# Altium schematic sheet ch340-usb-serial.SchDoc(ch340-usb-serial)
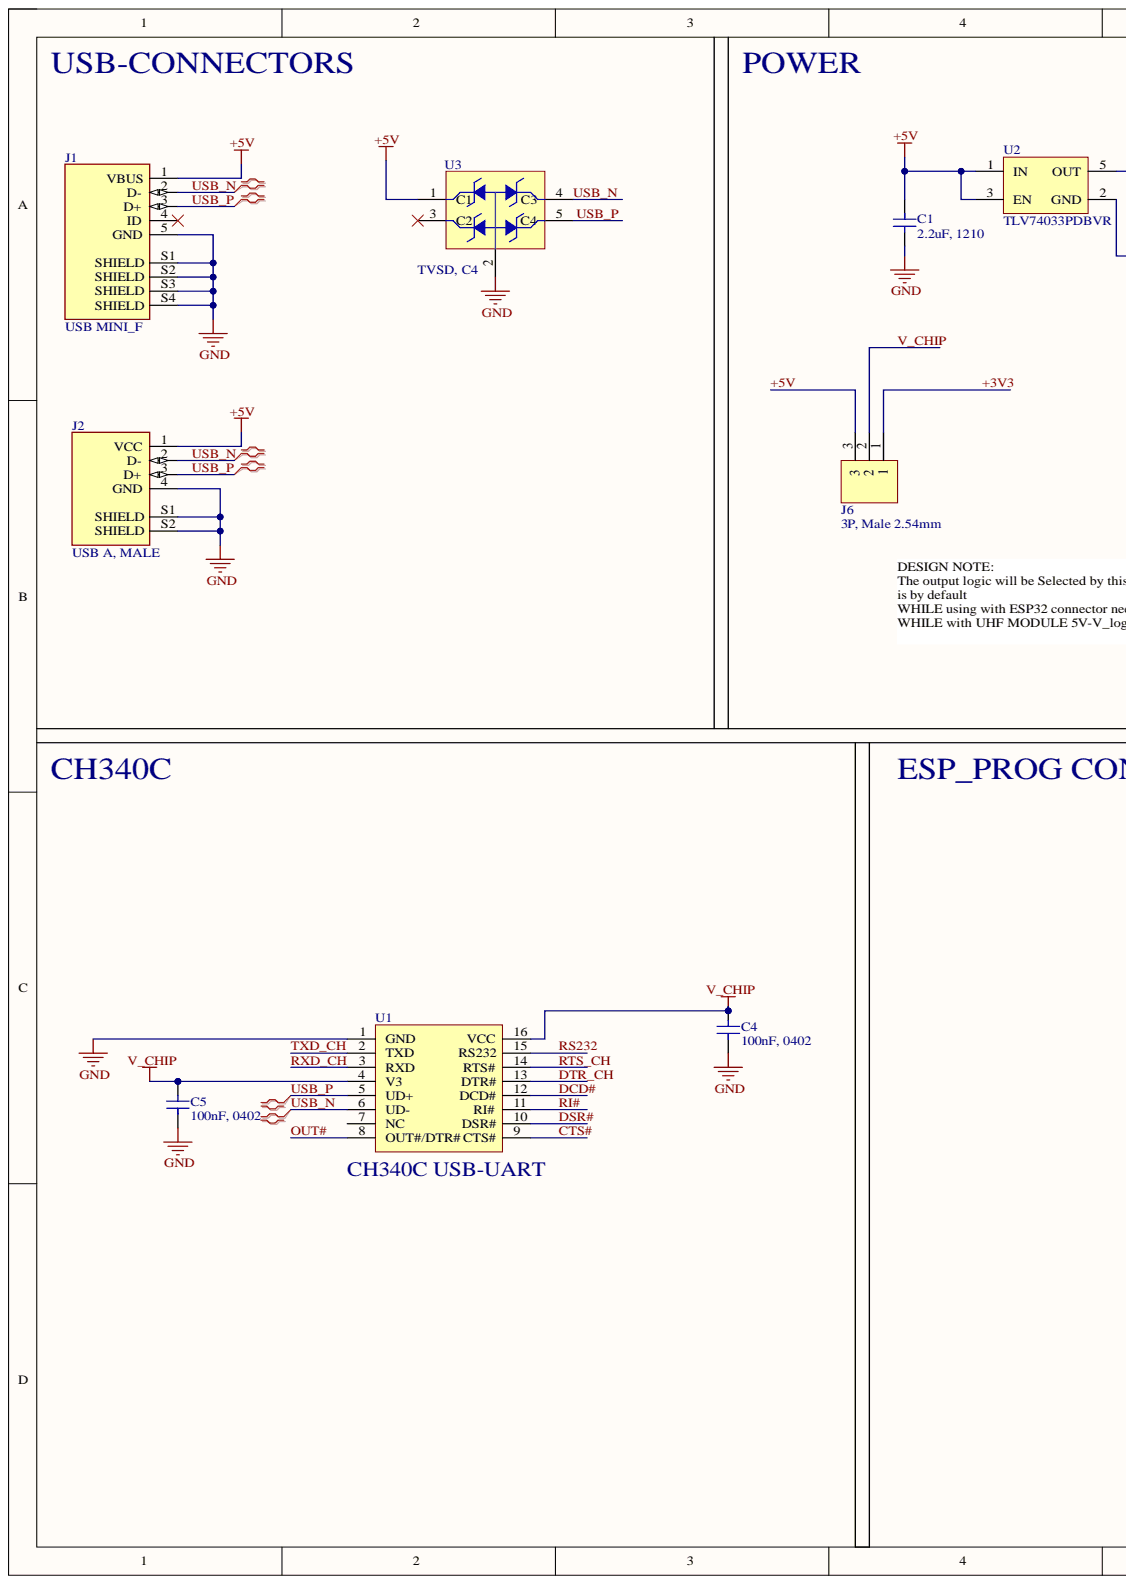
[diagram p0: ch340-usb-serial.SchDoc, left]
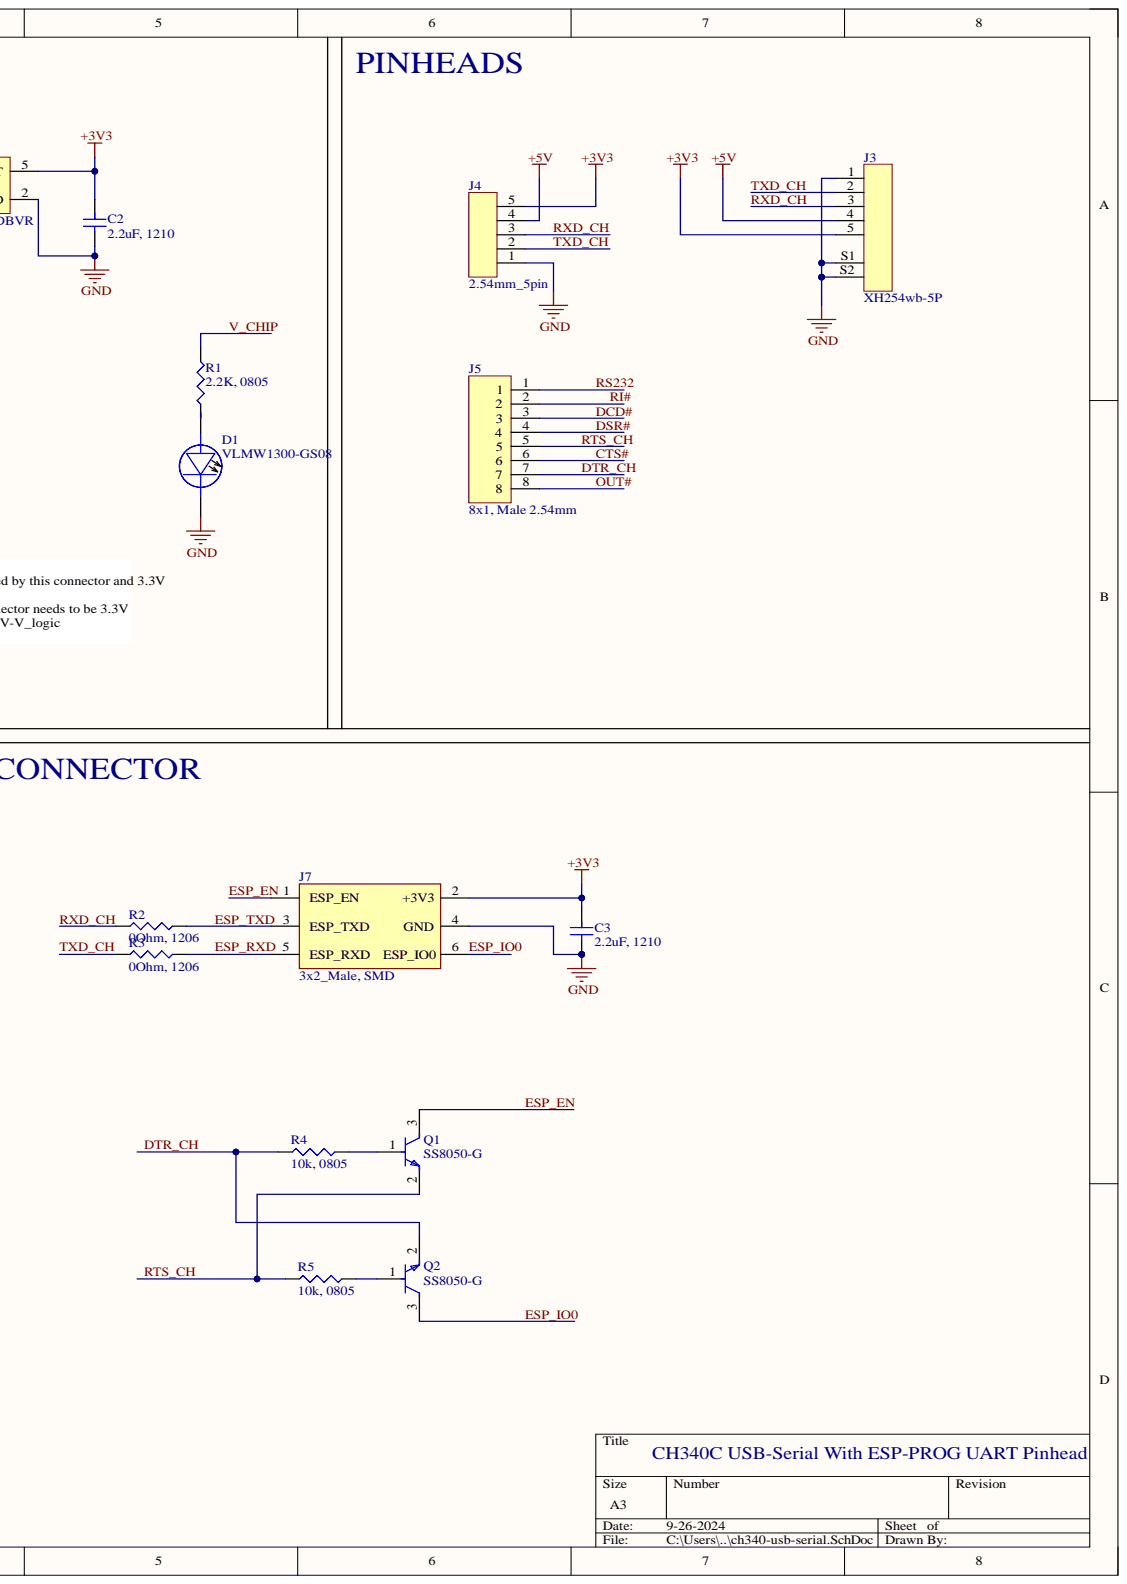
[diagram p1: ch340-usb-serial.SchDoc, right]

## Parts
C1  2.2uF, 1210  [CAP_1210]
C2  2.2uF, 1210  [CAP_1210]
C3  2.2uF, 1210  [CAP_1210]
C4  100nF, 0402  [CAP_0402]
C5  100nF, 0402  [CAP_0402]
D1  VLMW1300-GS08  [LED_0603]
J1  USB MINI_F  [USB_B_Female]
J2  USB A, MALE  Connector Type: USB  [USB_A_MALE]
J3  XH254wb-5P  [5PIN_Header_Connectotor]
J4  2.54mm_5pin  [5x1P_Male_2.54]
J5  8x1, Male 2.54mm  Contact Gender: Male  Terminal Pitch: 2.54mm  [8x1P_Male_2.54]
J6  3P, Male 2.54mm  Contact Gender: Male  Terminal Pitch: 2.54mm  [3x1P_Male_2.54]
J7  3x2_Male, SMD  [3x2P_Male_SMD_1.27]
Q1  SS8050-G  [FP-SS8050-G-IPC_B]
Q2  SS8050-G  [FP-SS8050-G-IPC_B]
R1  2.2K, 0805  [RES_0805]
R2  0Ohm, 1206  Case/Package: 1206  [RES_1206]
R3  0Ohm, 1206  Case/Package: 1206  [RES_1206]
R4  10k, 0805  Case/Package: 0805  [RES_0805]
R5  10k, 0805  Case/Package: 0805  [RES_0805]
U1  USB-UART  [SOP16]
U2  TLV74033PDBVR  [SOT95P280X145-5N]
U3  TVSD, C4  [SOT353]

## Nets
GND: C1.2 C2.2 C3.2 C4.1 C5.1 D1.K- J1.5(GND) J1.S1(SHIELD) J1.S2(SHIELD) J1.S3(SHIELD) J1.S4(SHIELD) J2.4(GND) J2.S1(SHIELD) J2.S2(SHIELD) J3.1 J3.S1 J3.S2 J4.1 J7.4(GND) U1.1(GND) U2.2(GND) U3.2
+3V3: C2.1 C3.1 J3.5 J4.5 J6.1 J7.2(+3V3) U2.5(OUT)
+5V: C1.1 J1.1(VBUS) J2.1(VCC) J3.4 J4.4 J6.3 U2.1(IN) U2.3(EN) U3.1(C1)
CTS#: J5.6 U1.9(CTS#)
DCD#: J5.3 U1.12(DCD#)
DSR#: J5.4 U1.10(DSR#)
DTR_CH: J5.7 Q2.2 R4.1 U1.13(DTR#)
ESP_EN: J7.1(ESP_EN) Q1.3
ESP_IO0: J7.6(ESP_IO0) Q2.3
ESP_RXD: J7.5(ESP_RXD) R3.2
ESP_TXD: J7.3(ESP_TXD) R2.2
OUT#: J5.8 U1.8(OUT#/DTR#)
RI#: J5.2 U1.11(RI#)
RS232: J5.1 U1.15(RS232)
RTS_CH: J5.5 Q1.2 R5.1 U1.14(RTS#)
RXD_CH: J3.3 J4.3 R2.1 U1.3(RXD)
TXD_CH: J3.2 J4.2 R3.1 U1.2(TXD)
USB_N: J1.2(D-) J2.2(D-) U1.6(UD-) U3.4(C3)
USB_P: J1.3(D+) J2.3(D+) U1.5(UD+) U3.5
V_CHIP: C4.2 C5.2 J6.2 R1.2 U1.4(V3) U1.16(VCC)
NetD1_A+: D1.A+ R1.1
NetQ1_1: Q1.1 R4.2
NetQ2_1: Q2.1 R5.2

Not connected: J1 4(ID); U1 7(NC); U3 3(C2)

## Library data of the parts
C1-C3 = CL32B225KCJSNNE: CAP CER 2.2UF 100V X7R 1210; Manufacturer: Samsung; Capacitor Type: Ceramic; Multilayer: Yes; Tolerance  (fill in as +-): 10%; Case/Package: 1210; Category: Ceramic Capacitors; Component Kind: Standard; ComponentLink1Description: https://datasheet.ciiva.com:443/pdfs/VipMasterIC/IC/SEMS/SEMS-S-A0008526420/SEMS-S-A0008525306-1.pdf?src-supplier=IHS+Markit; ComponentLink1URL: https://datasheet.ciiva.com:443/pdfs/VipMasterIC/IC/SEMS/SEMS-S-A0008526420/SEMS-S-A0008525306-1.pdf?src-supplier=IHS+Markit; ComponentLink2Description: https://datasheet.ciiva.com/25791/cl32b225kcjsnne-25791527.pdf?src-supplier=Digikey; ComponentLink2URL: https://datasheet.ciiva.com/25791/cl32b225kcjsnne-25791527.pdf?src-supplier=Digikey; ComponentLink3Description: https://datasheet.ciiva.com/26947/getdatasheetpartid-357497-26947037.pdf?src-supplier=Verical; ComponentLink3URL: https://datasheet.ciiva.com/26947/getdatasheetpartid-357497-26947037.pdf?src-supplier=Verical; ComponentLink4Description: https://datasheet.ciiva.com/16437/cl32b225kcjsnne-16437175.pdf?src-supplier=Future+Electronics; ComponentLink4URL: https://datasheet.ciiva.com/16437/cl32b225kcjsnne-16437175.pdf?src-supplier=Future+Electronics; Dielectric Material: Ceramic; JESD-609 Code: e3; Library Name: Schlib.SchLib; Manufacturer Part Number: CL32B225KCJSNNE; Max Operating Temperature: 125°C; Min Operating Temperature: -55°C; Mounting Technology: Surface Mount; Package Shape: Rectangular; Packaging: Tape and Reel; Pins: 2; Pricing: 1=0.43, 10=0.286, 50=0.228, 100=0.1882, 500=0.14706 (USD); Rated DC Voltage (URdc): 100V; Reach SVHC Compliant: Yes; RoHS: Yes; RoHS Compliant: Yes; Stock: 239814; Supplier: Digikey; Supplier Part Number: 1276-3362-6-ND; Temperature Characteristics Code: X7R; Terminal Finish: Nickel; Termination: Wraparound; Tolerance (fill in as +-): 10%; Value: 2.2uF
C4 C5 = CL05B104KB54PNC: 0.1 µF ±10% 50V Ceramic Capacitor X7R 0402 (1005 Metric); Manufacturer: Samsung; Material: 4241ConductorMaterial; Case/Package: 343Case; Category: Ceramic Capacitors; Component Kind: Standard; ComponentLink1Description: https://datasheet.octopart.com/CL05B104KB54PNC-Samsung-datasheet-8326407.pdf?src-supplier=TME; ComponentLink1URL: https://datasheet.octopart.com/CL05B104KB54PNC-Samsung-datasheet-8326407.pdf?src-supplier=TME; Height: 550um; LCSC Part Number: C307331; Library Name: Schlib.SchLib; Manufacturer Part Number: CL05B104KB54PNC; Max Operating Temperature: 125°C; Min Operating Temperature: -55°C; Pricing: 10000=0.0057 (USD); RoHS: Yes; RoHS Compliant: Yes; Stock: 2120000; Supplier: Arrow Electronics; Supplier Part Number: CL05B104KB54PNC; Tolerance: 10%; Value: 100nF; Voltage Rating: 50V
D1 = VLMW1300-GS08: LED COOL WHITE 0603 SMD; Manufacturer: Vishay Semiconductors; Additional Feature: HIGH RELIABILITY; Channels: 1; Forward Current: 20mA; Forward Voltage: 3.15V; Luminous Intensity-Nom: 112.5mcd; Optoelectronic Device Type: SINGLE COLOR LED; Overall Height: 0.55mm; Shape: Rectangular; Viewing Angle: 130°; Category: LEDs; Color: White; Color@wavelength: White; Component Kind: Standard; ComponentLink1Description: https://datasheet.ciiva.com:443/pdfs/VipMasterIC/IC/VISH/VISH-S-A0001807432/VISH-S-A0001807432-1.pdf?src-supplier=IHS+Markit; ComponentLink1URL: https://datasheet.ciiva.com:443/pdfs/VipMasterIC/IC/VISH/VISH-S-A0001807432/VISH-S-A0001807432-1.pdf?src-supplier=IHS+Markit; ComponentLink2Description: https://datasheet.ciiva.com/6858/vlmw1300-6858354.pdf?src-supplier=Newark; ComponentLink2URL: https://datasheet.ciiva.com/6858/vlmw1300-6858354.pdf?src-supplier=Newark; ComponentLink3Description: https://datasheet.ciiva.com/4688/vlmw1300-98499-4688159.pdf?src-supplier=Mouser; ComponentLink3URL: https://datasheet.ciiva.com/4688/vlmw1300-98499-4688159.pdf?src-supplier=Mouser; ComponentLink4Description: https://datasheet.ciiva.com/14650/vlmw1300-14650411.pdf?src-supplier=Element14; ComponentLink4URL: https://datasheet.ciiva.com/14650/vlmw1300-14650411.pdf?src-supplier=Element14; ComponentLink5Description: https://datasheet.ciiva.com/14650/vlmw1300-14650412.pdf?src-supplier=Element14; ComponentLink5URL: https://datasheet.ciiva.com/14650/vlmw1300-14650412.pdf?src-supplier=Element14; ComponentLink6Description: https://datasheet.ciiva.com/16388/vlmw1300-16388055.pdf?src-supplier=Future+Electronics; ComponentLink6URL: https://datasheet.ciiva.com/16388/vlmw1300-16388055.pdf?src-supplier=Future+Electronics; Library Name: Schlib.SchLib; Manufacturer Part Number: VLMW1300-GS08; Max Operating Temperature: 80°C; Min Operating Temperature: -20°C; Mounting Technology: Surface Mount; Packaging: Tape and Reel; Pins: 2; Pricing: 1=0.46, 10=0.266, 100=0.1687, 500=0.13056, 1000=0.11887 (USD); Reach SVHC Compliant: Yes; RoHS: Yes; RoHS Compliant: Yes; Size: 1.1mm; Stock: 7313; Supplier: Digikey; Supplier Part Number: VLMW1300-GS08CT-ND; Terminal Pitch: 1.5mm
J1 = GMSB0532112YEU: MINI USB B TYPE RECEPTACLE 5POS; Manufacturer: Amphenol; Category: Connectors; Component Kind: Standard; Library Name: Schlib.SchLib; Manufacturer Part Number: GMSB0532112YEU; Pricing: 1=0.55, 10=0.476, 25=0.4352, 50=0.4094, 100=0.3924, 250=0.35824, 500=0.34118 (USD); RoHS: No; Stock: 5615; Supplier: Digikey; Supplier Part Number: 664-GMSB0532112YEUDKR-ND
J2 = 0480372200: CONN PLUG USB2.0 TYPEA 4P SMD RA; Manufacturer: Molex; DIN Conformance: No; Mating Information: MULTIPLE MATING PARTS AVAILABLE; Number of Contacts: 4; Option: General Purpose; Body/Shell Style: PLUG; Component Kind: Standard; ComponentLink1Description: https://datasheet.ciiva.com:443/pdfs/VipMasterIC/IC/MOLE/MOLES33218/MOLES28897-1.pdf?src-supplier=IHS+Markit; ComponentLink1URL: https://datasheet.ciiva.com:443/pdfs/VipMasterIC/IC/MOLE/MOLES33218/MOLES28897-1.pdf?src-supplier=IHS+Markit; Contact Finish - Mating: GOLD (30); Contact Finish - Termination: TIN; Contact Gender: Male; Empty Shell: No; Filter Feature: No; IEC Conformance: No; JESD-609 Code: e3; Library Name: Schlib.SchLib; Manufacturer Part Number: 0480372200; MIL Conformance: No; Mixed Contacts: No; Mounting Style: RIGHT ANGLE; Mounting Technology: Panel,Board; Pricing: 1=1.45, 10=1.199, 100=0.9993 (USD); Reach SVHC Compliant: Yes; RoHS: Yes; RoHS Compliant: Yes; Stock: 18158; Supplier: Digikey; Supplier Part Number: WM3983CT-ND; Termination: SMD/SMT
J3 = XH254wb-5P: SMT SMD Horizontal Socket Male Header Connector; Component Kind: Standard; Library Name: Schlib.SchLib
J4 = 2.54mm_5pin: CONN HEADER VERT 5POS 2.54MM; Component Kind: Standard; Library Name: Schlib.SchLib
J5 = 61300811121: CONN HEADER VERT 8POS 2.54MM; Manufacturer: Wurth Electronics; Manufacturer Series: 613; Body Breadth: 0.1inch; Body Length: 0.8inch; Body/Shell Style: PLUG; Connector Type: BOARD CONNECTOR; Contact Pattern: RECTANGULAR; Contact Resistance: 20mR; Contact Style: SQ PIN-SKT; Dielectric Withstanding Voltage (AC): 500V; Insulation Resistance: 1GR; Mounting Option 1: LOCKING; Number Of Connectors: 1; Number of Contacts: 8; Rated Current (Signal): 3A; Reference Standard: UL; Reliability: COMMERCIAL; Body Depth: 0.1inch; Category: Headers and Wire Housings; Component Kind: Standard; ComponentLink1Description: https://datasheet.ciiva.com:443/pdfs/VipMasterIC/IC/MIDC/MIDCS07676/MIDCS07676-1.pdf?src-supplier=IHS+Markit; ComponentLink1URL: https://datasheet.ciiva.com:443/pdfs/VipMasterIC/IC/MIDC/MIDCS07676/MIDCS07676-1.pdf?src-supplier=IHS+Markit; ComponentLink2Description: https://datasheet.octopart.com/61300811121-W%C3%BCrth-Elektronik-datasheet-21154836.pdf?src-supplier=Element14; ComponentLink2URL: https://datasheet.octopart.com/61300811121-W%C3%BCrth-Elektronik-datasheet-21154836.pdf?src-supplier=Element14; ComponentLink3Description: https://katalog.we-online.de/em/datasheet/6130xx11121.pdf?src-supplier=Digikey; ComponentLink3URL: https://katalog.we-online.de/em/datasheet/6130xx11121.pdf?src-supplier=Digikey; Contact Finish - Mating: AU; Contact Finish - Termination: Gold (Au) - with Nickel (Ni) barrier; Contact Material: Copper Alloy; Insulation Color: Black; Insulation Material: Polybutylene; JESD-609 Code: e4; Library Name: Schlib.SchLib; Manufacturer Part Number: 61300811121; Mating Contact Pitch: 0.1inch; Max Operating Temperature: 125°C; Min Operating Temperature: -40°C; Mounting Style: STRAIGHT; Mounting Technology: Board; Number of Rows Loaded: 1; PCB Contact Pattern: RECTANGULAR; Pricing: 1=0.39, 10=0.336, 25=0.28, 50=0.234, 100=0.212, 1000=0.193 (USD); Reach SVHC Compliant: Yes; RoHS: Yes; RoHS Compliant: Yes; Stock: 8009; Supplier: Digikey; Supplier Part Number: 732-5321-ND; Terminal Length: 0.118inch; Termination: Solder
J6 = 61300311121: CONN HEADER VERT 3POS 2.54MM; Manufacturer: Wurth Electronics; Body Breadth: 0.1inch; Body Length: 0.3inch; Body/Shell Style: PLUG; Connector Type: BOARD CONNECTOR; Contact Pattern: RECTANGULAR; Contact Resistance: 20mR; Contact Style: SQ PIN-SKT; Dielectric Withstanding Voltage (AC): 500V; Insulation Resistance: 1GR; Mounting Option 1: LOCKING; Number Of Connectors: 1; Number of Contacts: 3; Rated Current (Signal): 3A; Reference Standard: UL; Reliability: COMMERCIAL; Body Depth: 0.1inch; Category: Headers and Wire Housings; Component Kind: Standard; ComponentLink1Description: https://datasheet.ciiva.com:443/pdfs/VipMasterIC/IC/MIDC/MIDCS07676/MIDCS07676-1.pdf?src-supplier=IHS+Markit; ComponentLink1URL: https://datasheet.ciiva.com:443/pdfs/VipMasterIC/IC/MIDC/MIDCS07676/MIDCS07676-1.pdf?src-supplier=IHS+Markit; ComponentLink2Description: http://www.farnell.com/cad/1737268.pdf?src-supplier=Element14; ComponentLink2URL: http://www.farnell.com/cad/1737268.pdf?src-supplier=Element14; ComponentLink3Description: https://datasheet.octopart.com/61300311121-W%C3%BCrth-Elektronik-datasheet-21154836.pdf?src-supplier=Element14; ComponentLink3URL: https://datasheet.octopart.com/61300311121-W%C3%BCrth-Elektronik-datasheet-21154836.pdf?src-supplier=Element14; ComponentLink4Description: https://katalog.we-online.de/em/datasheet/6130xx11121.pdf?src-supplier=Digikey; ComponentLink4URL: https://katalog.we-online.de/em/datasheet/6130xx11121.pdf?src-supplier=Digikey; Contact Finish - Mating: GOLD; Contact Finish - Termination: Gold (Au) - with Nickel (Ni) barrier; Contact Material: Copper Alloy; Insulation Color: Black; Insulation Material: Polybutylene; JESD-609 Code: e4; Library Name: Schlib.SchLib; Manufacturer Part Number: 61300311121; Mating Contact Pitch: 0.1inch; Max Operating Temperature: 125°C; Min Operating Temperature: -40°C; Mounting Style: STRAIGHT; Mounting Technology: Board; Number of Rows Loaded: 1; PCB Contact Pattern: RECTANGULAR; Pricing: 1=0.13, 10=0.113, 25=0.094, 50=0.079, 100=0.071, 1000=0.066 (USD); Reach SVHC Compliant: Yes; RoHS: Yes; RoHS Compliant: Yes; Stock: 134142; Supplier: Digikey; Supplier Part Number: 732-5316-ND; Terminal Length: 0.118inch; Termination: Solder
J7 = 20021121-00006C4LF: CONN HEADER SMD 6POS 1.27MM; Manufacturer: Amphenol Communications Solutions; Category: Headers and Wire Housings; Component Kind: Standard; Library Name: Schlib.SchLib; Manufacturer Part Number: 20021121-00006C4LF; Pricing: 1=0.8, 10=0.612, 100=0.4694 (USD); RoHS: No; Stock: 7493; Supplier: Digikey; Supplier Part Number: 609-3693-1-ND
Q1 Q2 = SS8050-G: TRANS NPN 25V 1.5A SOT23-3; Manufacturer: Comchip; Category: Transistors; Component Kind: Standard; ComponentLink1Description: https://datasheet.ciiva.com:443/pdfs/VipMasterIC/IC/COMC/COMC-S-A0004994192/COMC-S-A0004994192-1.pdf?src-supplier=IHS+Markit; ComponentLink1URL: https://datasheet.ciiva.com:443/pdfs/VipMasterIC/IC/COMC/COMC-S-A0004994192/COMC-S-A0004994192-1.pdf?src-supplier=IHS+Markit; ComponentLink2Description: http://www.comchiptech.com/admin/files/product/SS8050-G RevA181526.pdf?src-supplier=Digikey; ComponentLink2URL: http://www.comchiptech.com/admin/files/product/SS8050-G RevA181526.pdf?src-supplier=Digikey; LCSC Part Number: C164886; Library Name: Schlib.SchLib; Manufacturer Part Number: SS8050-G; Pricing: 1=0.29, 10=0.2, 100=0.0978, 500=0.08154, 1000=0.05666 (USD); Reach SVHC Compliant: Yes; RoHS: Yes; RoHS Compliant: Yes; Stock: 90458; Supplier: Digikey; Supplier Part Number: 641-1790-1-ND
R1 = CRCW08052K20FKEC: RES SMD 2.2K OHM 1% 1/8W 0805; Manufacturer: Vishay Dale; Package Height: 0.45mm; Package Length: 2mm; Package Width: 1.25mm; Power: 125mW; Rated Temperature: 70°C; Reference Standard: AEC-Q200; Temperature Coefficient: 100ppm/°C; Value: 2.2kR; Working Voltage: 150V; Case/Package: 0805; Category: Chip SMD Resistors; Component Kind: Standard; ComponentLink1Description: https://datasheet.ciiva.com:443/pdfs/VipMasterIC/IC/VISH/VISH-S-A0007266705/VISH-S-A0007266705-1.pdf?src-supplier=IHS+Markit; ComponentLink1URL: https://datasheet.ciiva.com:443/pdfs/VipMasterIC/IC/VISH/VISH-S-A0007266705/VISH-S-A0007266705-1.pdf?src-supplier=IHS+Markit; ComponentLink2Description: http://www.vishay.com/docs/20035/dcrcwe3.pdf?src-supplier=Digikey; ComponentLink2URL: http://www.vishay.com/docs/20035/dcrcwe3.pdf?src-supplier=Digikey; Construction: Chip; JESD-609 Code: e3; Library Name: Schlib.SchLib; Manufacturer Part Number: CRCW08052K20FKEC; Max Operating Temperature: 155°C; Min Operating Temperature: -55°C; Mounting Technology: Surface Mount; Package Shape: Rectangular; Package Style: SMTMeter; Packaging: Tape and Reel; Pins: 2; Pricing: 1=0.1, 10=0.039, 50=0.025, 100=0.021, 500=0.01444, 1000=0.0125, 5000=0.00933, 10000=0.00838 (USD); Reach SVHC Compliant: Yes; Resistor Type: FIXED RESISTOR; RoHS: Yes; RoHS Compliant: Yes; Stock: 19945; Supplier: Digikey; Supplier Part Number: 541-CRCW08052K20FKECCT-ND; Technology: METAL GLAZE/THICK FILM; Terminal Finish: Nickel; Termination: Wraparound; Tolerance: 1%
R2 R3 = RC1206JR-070RL: RES 0 OHM JUMPER 1/4W 1206; Manufacturer: Yageo; Package Height: 0.55mm; Package Length: 3.1mm; Package Width: 1.6mm; Power: 250mW; Rated Temperature: 70°C; Value: 0R; Category: Chip SMD Resistors; Component Kind: Standard; ComponentLink1Description: https://datasheet.ciiva.com:443/pdfs/VipMasterIC/IC/YAGO/YAGO-S-A0008145938/YAGO-S-A0008145938-1.pdf?src-supplier=IHS+Markit; ComponentLink1URL: https://datasheet.ciiva.com:443/pdfs/VipMasterIC/IC/YAGO/YAGO-S-A0008145938/YAGO-S-A0008145938-1.pdf?src-supplier=IHS+Markit; ComponentLink2Description: https://datasheet.ciiva.com/11808/0900766b80c6630a-11808156.pdf?src-supplier=Rs; ComponentLink2URL: https://datasheet.ciiva.com/11808/0900766b80c6630a-11808156.pdf?src-supplier=Rs; ComponentLink3Description: https://datasheet.ciiva.com/29181/402222-da-01-en-smd-widerstand-0-ohm-1206-29181568.pdf?src-supplier=Conrad; ComponentLink3URL: https://datasheet.ciiva.com/29181/402222-da-01-en-smd-widerstand-0-ohm-1206-29181568.pdf?src-supplier=Conrad; ComponentLink4Description: https://datasheet.ciiva.com/22747/1692832-22747541.pdf?src-supplier=Element14; ComponentLink4URL: https://datasheet.ciiva.com/22747/1692832-22747541.pdf?src-supplier=Element14; ComponentLink5Description: https://datasheet.ciiva.com/46445/444357-46445719.pdf?src-supplier=Element14; ComponentLink5URL: https://datasheet.ciiva.com/46445/444357-46445719.pdf?src-supplier=Element14; ComponentLink6Description: https://datasheet.ciiva.com/17035/pyu-rc1206-51-rohs-l-4-17035512.pdf?src-supplier=Future+Electronics; ComponentLink6URL: https://datasheet.ciiva.com/17035/pyu-rc1206-51-rohs-l-4-17035512.pdf?src-supplier=Future+Electronics; Construction: Chip; JESD-609 Code: e3; Library Name: Schlib.SchLib; Manufacturer Part Number: RC1206JR-070RL; Max Operating Temperature: 155°C; Min Operating Temperature: -55°C; Mounting Technology: Surface Mount; Package Shape: Rectangular; Package Style: SMTMeter; Packaging: Tape and Reel; Pins: 2; Pricing: 1=0.1, 10=0.048, 25=0.0364, 50=0.0276, 100=0.0193, 250=0.01556, 500=0.01244, 1000=0.00915 (USD); Reach SVHC Compliant: Yes; Resistor Type: FIXED RESISTOR; RoHS: Yes; RoHS Compliant: Yes; Stock: 903635; Supplier: Digikey; Supplier Part Number: 311-0.0ERCT-ND; Technology: METAL GLAZE/THICK FILM; Terminal Finish: Nickel; Termination: Wraparound
R4 R5 = RC0805FR-0710KL: RES 10K OHM 1% 1/8W 0805; Manufacturer: Yageo; Additional Feature: LASER TRIMMABLE; Package Height: 0.5mm; Package Length: 2mm; Package Width: 1.25mm; Power: 125mW; Rated Temperature: 70°C; Temperature Coefficient: 100ppm/°C; Value: 10kR; Working Voltage: 150V; Category: Chip SMD Resistors; Component Kind: Standard; ComponentLink1Description: https://datasheet.ciiva.com:443/pdfs/VipMasterIC/IC/YAGO/YAGO-S-A0008145938/YAGO-S-A0008145938-1.pdf?src-supplier=IHS+Markit; ComponentLink1URL: https://datasheet.ciiva.com:443/pdfs/VipMasterIC/IC/YAGO/YAGO-S-A0008145938/YAGO-S-A0008145938-1.pdf?src-supplier=IHS+Markit; Construction: Chip; JESD-609 Code: e3; Library Name: Schlib.SchLib; Manufacturer Part Number: RC0805FR-0710KL; Max Operating Temperature: 155°C; Min Operating Temperature: -55°C; Mounting Technology: Surface Mount; Package Shape: Rectangular; Package Style: SMTMeter; Packaging: Tape and Reel; Pins: 2; Pricing: 1=0.1, 10=0.027, 50=0.017, 100=0.0142, 500=0.00976, 1000=0.00844 (USD); Reach SVHC Compliant: Yes; Resistor Type: FIXED RESISTOR; RoHS: Yes; RoHS Compliant: Yes; Stock: 1781403; Supplier: Digikey; Supplier Part Number: 311-10.0KCRCT-ND; Technology: METAL GLAZE/THICK FILM; Terminal Finish: Nickel; Termination: Wraparound; Tolerance: 1%
U1 = CH340C: SOP-16 USB to UART Converters; Component Kind: Standard; Library Name: Schlib.SchLib
U2 = TLV74033PDBVR: IC REG LINEAR 3.3V 300MA SOT23-5; Component Kind: Standard; Library Name: Schlib.SchLib
U3 = DF5A5.6FUTE85LF: TVS Quad Common Anode 5.6V 200mW 5-Pin SOT-353 Embossed T/R; Manufacturer: Toshiba; Category: TVS Diodes; Component Kind: Standard; ComponentLink1Description: https://datasheet.ciiva.com/29853/dst-df5a5-6fu-tde-en-9420-29853417.pdf?src-supplier=Digikey; ComponentLink1URL: https://datasheet.ciiva.com/29853/dst-df5a5-6fu-tde-en-9420-29853417.pdf?src-supplier=Digikey; ComponentLink2Description: https://datasheet.ciiva.com/29853/dst-df5a5-6fu-tde-en-9420-29853419.pdf?src-supplier=Digikey; ComponentLink2URL: https://datasheet.ciiva.com/29853/dst-df5a5-6fu-tde-en-9420-29853419.pdf?src-supplier=Digikey; ComponentLink3Description: https://datasheet.ciiva.com/29853/dst-df5a5-6fu-tde-en-9420-29853418.pdf?src-supplier=Digikey; ComponentLink3URL: https://datasheet.ciiva.com/29853/dst-df5a5-6fu-tde-en-9420-29853418.pdf?src-supplier=Digikey; Library Name: Schlib.SchLib; Manufacturer Part Number: DF5A5.6FUTE85LF; Pricing: 1=0.34, 10=0.21, 100=0.1318, 500=0.09776, 1000=0.08675 (USD); RoHS: Yes; Stock: 11607; Supplier: Digikey; Supplier Part Number: DF5A5.6FUTE85LFCT-ND
